# Altium schematic sheet user_interface.SchDoc(user_interface)
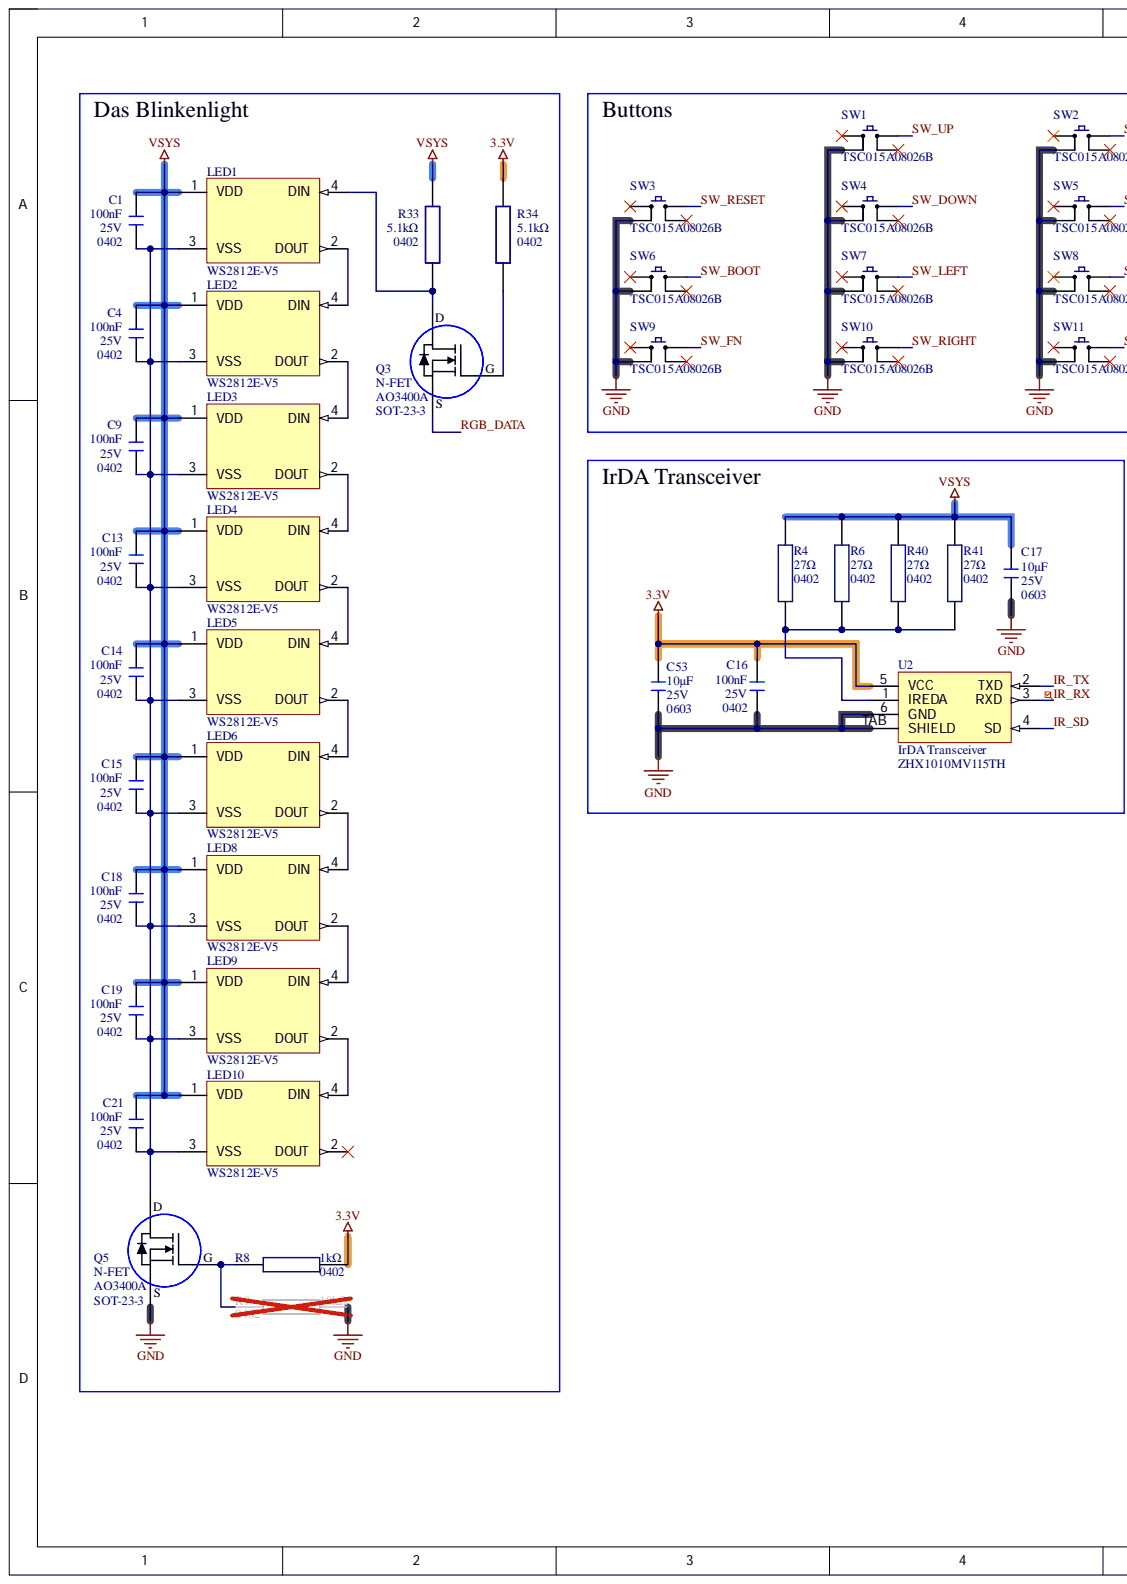
[diagram p0: user_interface.SchDoc, left]
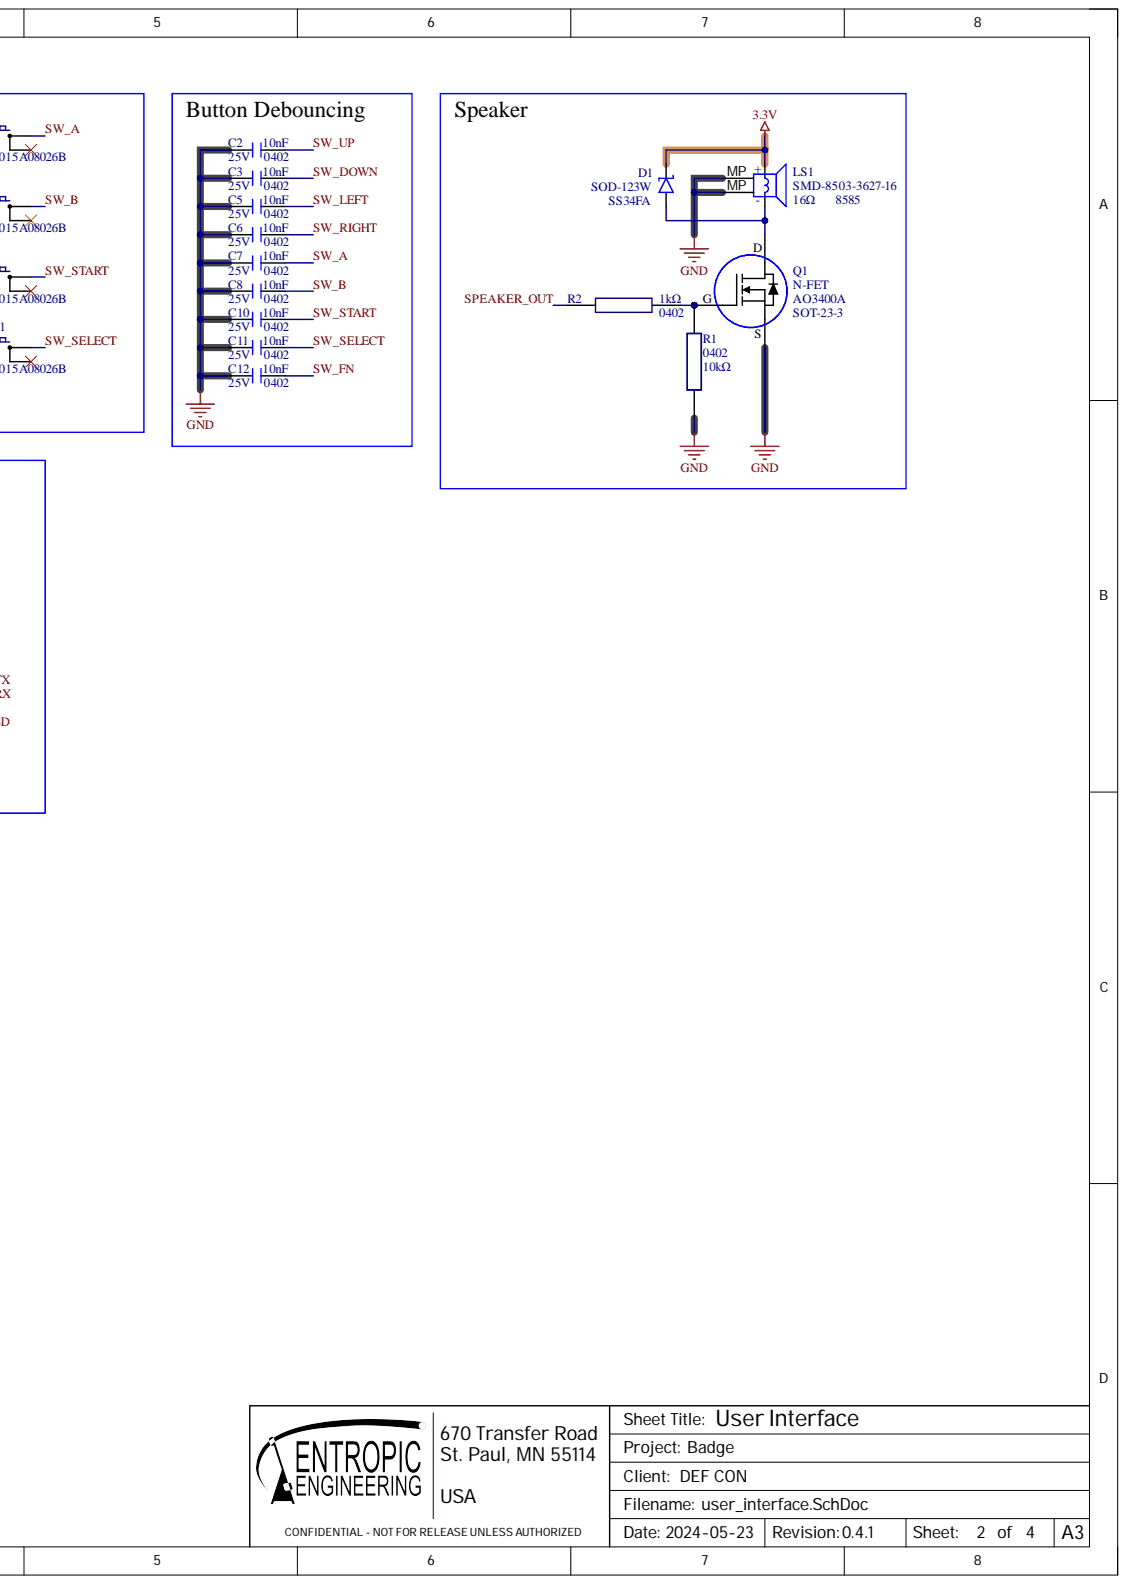
[diagram p1: user_interface.SchDoc, right]

## Parts
C1  Capacitance: 100nF  Case Code (Imperial): 0402  Voltage: 25V  [0402_capacitor]  (hidden comment: GRM155R71E104KE14D)
C2  Capacitance: 10nF  Case Code (Imperial): 0402  Voltage Rating: 25V  [0402_capacitor]  (hidden comment: CL05B103KA5NNNC)
C3  Capacitance: 10nF  Case Code (Imperial): 0402  Voltage Rating: 25V  [0402_capacitor]  (hidden comment: CL05B103KA5NNNC)
C4  Capacitance: 100nF  Case Code (Imperial): 0402  Voltage: 25V  [0402_capacitor]  (hidden comment: GRM155R71E104KE14D)
C5  Capacitance: 10nF  Case Code (Imperial): 0402  Voltage Rating: 25V  [0402_capacitor]  (hidden comment: CL05B103KA5NNNC)
C6  Capacitance: 10nF  Case Code (Imperial): 0402  Voltage Rating: 25V  [0402_capacitor]  (hidden comment: CL05B103KA5NNNC)
C7  Capacitance: 10nF  Case Code (Imperial): 0402  Voltage Rating: 25V  [0402_capacitor]  (hidden comment: CL05B103KA5NNNC)
C8  Capacitance: 10nF  Case Code (Imperial): 0402  Voltage Rating: 25V  [0402_capacitor]  (hidden comment: CL05B103KA5NNNC)
C9  Capacitance: 100nF  Case Code (Imperial): 0402  Voltage: 25V  [0402_capacitor]  (hidden comment: GRM155R71E104KE14D)
C10  Capacitance: 10nF  Case Code (Imperial): 0402  Voltage Rating: 25V  [0402_capacitor]  (hidden comment: CL05B103KA5NNNC)
C11  Capacitance: 10nF  Case Code (Imperial): 0402  Voltage Rating: 25V  [0402_capacitor]  (hidden comment: CL05B103KA5NNNC)
C12  Capacitance: 10nF  Case Code (Imperial): 0402  Voltage Rating: 25V  [0402_capacitor]  (hidden comment: CL05B103KA5NNNC)
C13  Capacitance: 100nF  Case Code (Imperial): 0402  Voltage: 25V  [0402_capacitor]  (hidden comment: GRM155R71E104KE14D)
C14  Capacitance: 100nF  Case Code (Imperial): 0402  Voltage: 25V  [0402_capacitor]  (hidden comment: GRM155R71E104KE14D)
C15  Capacitance: 100nF  Case Code (Imperial): 0402  Voltage: 25V  [0402_capacitor]  (hidden comment: GRM155R71E104KE14D)
C16  Capacitance: 100nF  Case Code (Imperial): 0402  Voltage: 25V  [0402_capacitor]  (hidden comment: GRM155R71E104KE14D)
C17  value 10µF  Case Code (Imperial): 0603  Voltage Rating: 25V  [0603_capacitor]  (hidden comment: CL10A106MA8NRNC)
C18  Capacitance: 100nF  Case Code (Imperial): 0402  Voltage: 25V  [0402_capacitor]  (hidden comment: GRM155R71E104KE14D)
C19  Capacitance: 100nF  Case Code (Imperial): 0402  Voltage: 25V  [0402_capacitor]  (hidden comment: GRM155R71E104KE14D)
C21  Capacitance: 100nF  Case Code (Imperial): 0402  Voltage: 25V  [0402_capacitor]  (hidden comment: GRM155R71E104KE14D)
C53  value 10µF  Case Code (Imperial): 0603  Voltage Rating: 25V  [0603_capacitor]  (hidden comment: CL10A106MA8NRNC)
D1  SS34FA  Case/Package: SOD-123W  [SOD-123]
LED1  WS2812E-V5  [WS2812E-V5]
LED2  WS2812E-V5  [WS2812E-V5]
LED3  WS2812E-V5  [WS2812E-V5]
LED4  WS2812E-V5  [WS2812E-V5]
LED5  WS2812E-V5  [WS2812E-V5]
LED6  WS2812E-V5  [WS2812E-V5]
LED8  WS2812E-V5  [WS2812E-V5]
LED9  WS2812E-V5  [WS2812E-V5]
LED10  WS2812E-V5  [WS2812E-V5]
LS1  SMD-8503-3627-16  Resistance: 16Ω  [8585_speaker]
Q1  N-FET  Case/Package: SOT-23-3  [SOT95P280X115-3N]
Q3  N-FET  Case/Package: SOT-23-3  [SOT95P280X115-3N]
Q5  N-FET  Case/Package: SOT-23-3  [SOT95P280X115-3N]
R1  value 10kΩ  Case Code (Imperial): 0402  [0402_resistor]  (hidden comment: RC0402FR-0710KL)
R2  value 1kΩ  Case Code (Imperial): 0402  [0402_resistor]  (hidden comment: RC0402JR-071KL)
R4  Resistance: 27Ω  Size Code: 0402  [0402_resistor]  (hidden comment: RMCF0402FT27R0)
R6  Resistance: 27Ω  Size Code: 0402  [0402_resistor]  (hidden comment: RMCF0402FT27R0)
R8  value 1kΩ  Case Code (Imperial): 0402  [0402_resistor]  (hidden comment: RC0402JR-071KL)
R9  value 10kΩ  Case Code (Imperial): 0402  [0402_resistor]  (hidden comment: RC0402FR-0710KL)
R33  value 5.1kΩ  Case Code (Imperial): 0402  [0402_resistor]  (hidden comment: RC0402FR-075K1L)
R34  value 5.1kΩ  Case Code (Imperial): 0402  [0402_resistor]  (hidden comment: RC0402FR-075K1L)
R40  Resistance: 27Ω  Size Code: 0402  [0402_resistor]  (hidden comment: RMCF0402FT27R0)
R41  Resistance: 27Ω  Size Code: 0402  [0402_resistor]  (hidden comment: RMCF0402FT27R0)
SW1  Up  [TSC015A08026B]  (value not drawn)
SW2  A  [TSC015A08026B]  (value not drawn)
SW3  Reset  [TSC015A08026B]  (value not drawn)
SW4  Down  [TSC015A08026B]  (value not drawn)
SW5  B  [TSC015A08026B]  (value not drawn)
SW6  Boot  [TSC015A08026B]  (value not drawn)
SW7  Left  [TSC015A08026B]  (value not drawn)
SW8  Start  [TSC015A08026B]  (value not drawn)
SW9  FN  [TSC015A08026B]  (value not drawn)
SW10  Right  [TSC015A08026B]  (value not drawn)
SW11  Select  [TSC015A08026B]  (value not drawn)
U2  IrDA Transceiver  [ZHX1010]

## Nets
3.3V: C16.1 C53.2 D1.K LS1.+ R8.1 R34.2 U2.5(VCC)
GND: C2.1 C3.1 C5.1 C6.1 C7.1 C8.1 C10.1 C11.1 C12.1 C16.2 C17.1 C53.1 LS1.MP Q1.2 Q5.2 R1.2 R9.2 SW1.2 SW2.2 SW3.2 SW4.2 SW5.2 SW6.2 SW7.2 SW8.2 SW9.2 SW10.2 SW11.2 U2.6(GND) U2.TAB(SHIELD)
VSYS: C1.2 C4.2 C9.2 C13.2 C14.2 C15.2 C17.2 C18.2 C19.2 C21.2 LED1.1(VDD) LED2.1(VDD) LED3.1(VDD) LED4.1(VDD) LED5.1(VDD) LED6.1(VDD) LED8.1(VDD) LED9.1(VDD) LED10.1(VDD) R4.2 R6.2 R33.2 R40.2 R41.2
IR_RX: U2.3(RXD)
IR_SD: U2.4(SD)
IR_TX: U2.2(TXD)
RGB_DATA: Q3.2
SPEAKER_OUT: R2.1
SW_A: C7.2 SW2.3
SW_B: C8.2 SW5.3
SW_BOOT: SW6.3
SW_DOWN: C3.2 SW4.3
SW_FN: C12.2 SW9.3
SW_LEFT: C5.2 SW7.3
SW_RESET: SW3.3
SW_RIGHT: C6.2 SW10.3
SW_SELECT: C11.2 SW11.3
SW_START: C10.2 SW8.3
SW_UP: C2.2 SW1.3
NetC1_1: C1.1 C4.1 C9.1 C13.1 C14.1 C15.1 C18.1 C19.1 C21.1 LED1.3(VSS) LED2.3(VSS) LED3.3(VSS) LED4.3(VSS) LED5.3(VSS) LED6.3(VSS) LED8.3(VSS) LED9.3(VSS) LED10.3(VSS) Q5.3
NetD1_A: D1.A LS1.- Q1.3
NetLED1_2: LED1.2(DOUT) LED2.4(DIN)
NetLED1_4: LED1.4(DIN) Q3.3 R33.1
NetLED2_2: LED2.2(DOUT) LED3.4(DIN)
NetLED3_2: LED3.2(DOUT) LED4.4(DIN)
NetLED4_2: LED4.2(DOUT) LED5.4(DIN)
NetLED5_2: LED5.2(DOUT) LED6.4(DIN)
NetLED6_2: LED6.2(DOUT) LED8.4(DIN)
NetLED8_2: LED8.2(DOUT) LED9.4(DIN)
NetLED9_2: LED9.2(DOUT) LED10.4(DIN)
NetQ1_1: Q1.1 R1.1 R2.2
NetQ3_1: Q3.1 R34.1
NetQ5_1: Q5.1 R8.2 R9.1
NetR4_1: R4.1 R6.1 R40.1 R41.1 U2.1(IREDA)

Not connected: LED10 2(DOUT); SW1 1 4; SW2 1 4; SW3 1 4; SW4 1 4; SW5 1 4; SW6 1 4; SW7 1 4; SW8 1 4; SW9 1 4; SW10 1 4; SW11 1 4

## Library data of the parts
C1 C4 C9 C13-C16 C18 C19 C21 = GRM155R71E104KE14D: 100 nF, 25 V, X7R, 0402 Chip capacitor; house_part_number: C-100nF-0402-002; Manufacturer: Murata; Dielectric Material: Ceramic; Tolerance: 10%; Case Code (Metric): 1005; Case/Package: 0402; Category: Ceramic Capacitors; Component Kind: Standard; ComponentLink1Description: http://www.farnell.com/datasheets/1872206.pdf?src-supplier=Farnell; ComponentLink1URL: http://www.farnell.com/datasheets/1872206.pdf?src-supplier=Farnell; ComponentLink2Description: http://components.arrow.com/part/dataview/0323_06361249?src-supplier=Arrow+Europe; ComponentLink2URL: http://components.arrow.com/part/dataview/0323_06361249?src-supplier=Arrow+Europe; ComponentLink3Description: http://uk.rs-online.com/webdocs/144c/0900766b8144c113.pdf?src-supplier=RSComponents; ComponentLink3URL: http://uk.rs-online.com/webdocs/144c/0900766b8144c113.pdf?src-supplier=RSComponents; ComponentLink4Description: http://www.farnell.com/datasheets/2048008.pdf?src-supplier=Farnell; ComponentLink4URL: http://www.farnell.com/datasheets/2048008.pdf?src-supplier=Farnell; ComponentLink5Description: http://www.mouser.com/ds/2/281/c02e-2905.pdf?src-supplier=Mouser; ComponentLink5URL: http://www.mouser.com/ds/2/281/c02e-2905.pdf?src-supplier=Mouser; ComponentLink6Description: http://www.farnell.com/datasheets/2048008.pdf?src-supplier=Newark; ComponentLink6URL: http://www.farnell.com/datasheets/2048008.pdf?src-supplier=Newark; ComponentLink7Description: https://search.murata.co.jp/Ceramy/image/img/A01X/G101/ENG/GRM155R71E104KE14-01.pdf?src-supplier=Digi-Key; ComponentLink7URL: https://search.murata.co.jp/Ceramy/image/img/A01X/G101/ENG/GRM155R71E104KE14-01.pdf?src-supplier=Digi-Key; ComponentLink8Description: http://www.farnell.com/datasheets/2048008.pdf?src-supplier=Element14; ComponentLink8URL: http://www.farnell.com/datasheets/2048008.pdf?src-supplier=Element14; ComponentLink9Description: http://psearch.en.murata.com/capacitor/product/GRM155R71E104KE14%23.pdf?src-supplier=Avnet; ComponentLink9URL: http://psearch.en.murata.com/capacitor/product/GRM155R71E104KE14%23.pdf?src-supplier=Avnet; Depth: 0.5mm; Dielectric: X7R; Height: 0.5mm; Lead Free: Lead Free; Length: 1mm; Manufacturer Part Number: GRM155R71E104KE14D; Max Operating Temperature: 125°C; Min Operating Temperature: -55°C; Mount: Surface Mount; Package Quantity: 10000; Packaging: Tape and Reel; Pricing 1: 1=0.10000, 10=0.02700, 50=0.01460, 100=0.01190, 500=0.00848, 1000=0.00666, 2500=0.00605, 5000=0.00557 (USD); REACH SVHC: Unknown; Resistor Type: Ceramic; RoHS: Yes; RoHS Compliant: Yes; Supplier 1: Digi-Key; Supplier Part Number 1: 490-10698-1-ND; Termination: SMD/SMT; Thickness: 0.022inch; Voltage Rating: 25V; Voltage Rating (DC): 25V; Weight: 0.000212oz; Width: 0.02inch; Library Name: defcon_badge.SchLib
C2 C3 C5-C8 C10-C12 = CL05B103KA5NNNC: 10 nF, 25 V, X7R, 0402 Chip capacitor; house_part_number: C-10nF-0402-002; Manufacturer: Samsung; Tolerance: 10%; Case Code (Metric): 1005; Case/Package: 0402; Category: Ceramic Capacitors; Component Kind: Standard; ComponentLink1Description: https://datasheet.ciiva.com/15195/getdatasheetpartid-358612-15195635.pdf?src-supplier=Verical; ComponentLink1URL: https://datasheet.ciiva.com/15195/getdatasheetpartid-358612-15195635.pdf?src-supplier=Verical; ComponentLink2Description: https://datasheet.ciiva.com/16740/cl05b104kq5nnnc-16740683.pdf?src-supplier=Future+Electronics; ComponentLink2URL: https://datasheet.ciiva.com/16740/cl05b104kq5nnnc-16740683.pdf?src-supplier=Future+Electronics; Construction: Flat; Contact Plating: Tin; Depth: 0.5mm; Dielectric: X7R; Height: 0.5mm; Length: 1mm; Manufacturer Part Number: CL05B103KA5NNNC; Max Operating Temperature: 125°C; Min Operating Temperature: -55°C; Mount: Surface Mount; Package Quantity: 1; Packaging: Tape and Reel; Pricing 1: 10000=0.00328, 20000=0.00312, 30000=0.00297, 50000=0.00266, 100000=0.00215, 250000=0.00195 (USD); Radiation Hardening: No; RoHS: Yes; RoHS Compliant: Yes; Series: CL; Stock 1: 2318300; Supplier 1: Digi-Key; Supplier Part Number 1: 1276-1057-2-ND; Thickness: 0.022inch; Width: 0.02inch; Library Name: defcon_badge.SchLib
C17 C53 = CL10A106MA8NRNC: 10 µF, 25 V, X5R, 0603 Chip capacitor; house_part_number: C-10uF-0603-002; Manufacturer: Samsung; Material: 4241ConductorMaterial; Tolerance: 20%; Case Code (Metric): 1608; Case/Package: 0603; Category: Ceramic Capacitors; Component Kind: Standard; ComponentLink1Description: https://datasheet.ciiva.com/25766/cl10a106ma8nrnc-25766562.pdf?src-supplier=Digikey; ComponentLink1URL: https://datasheet.ciiva.com/25766/cl10a106ma8nrnc-25766562.pdf?src-supplier=Digikey; Height: 900um; Lead Free: Lead Free; Manufacturer Part Number: CL10A106MA8NRNC; Max Operating Temperature: 85°C; Min Operating Temperature: -55°C; Mounting Technology: Surface Mount; Packaging: Cut Tape; Pricing: 4000=0.0511, 8000=0.046, 12000=0.0434, 28000=0.0413, 100000=0.0356 (USD); RoHS: Yes; RoHS Compliant: Yes; Series: CL; Stock: 632000; Supplier 1: Arrow Electronics; Supplier Part Number 1: CL10A106MA8NRNC; Library Name: defcon_badge.SchLib
D1 = SS34FA: 40 V, 3 A Schottky Rectifier, SOD-123FA; house_part_number: D-SCHOTTKY-3A-SOD123F-002; Manufacturer: ON Semiconductor / Fairchild; Average Rectified Current: 3A; Element Configuration: Single; Max Forward Surge Current (Ifsm): 80A; Max Repetitive Reverse Voltage (Vrrm): 40V; Category: Schottky Diodes; Component Kind: Standard; ComponentLink1Description: http://www.farnell.com/datasheets/2552647.pdf?src-supplier=Farnell; ComponentLink1URL: http://www.farnell.com/datasheets/2552647.pdf?src-supplier=Farnell; ComponentLink2Description: http://www.mouser.com/ds/2/149/SS34FA-1012641.pdf?src-supplier=Mouser; ComponentLink2URL: http://www.mouser.com/ds/2/149/SS34FA-1012641.pdf?src-supplier=Mouser; ComponentLink3Description: http://www.farnell.com/datasheets/2552647.pdf?src-supplier=Element14; ComponentLink3URL: http://www.farnell.com/datasheets/2552647.pdf?src-supplier=Element14; ComponentLink4Description: http://www.farnell.com/datasheets/2552647.pdf?src-supplier=Newark; ComponentLink4URL: http://www.farnell.com/datasheets/2552647.pdf?src-supplier=Newark; ComponentLink5Description: https://www.fairchildsemi.com/datasheets/SS/SS34FA.pdf?src-supplier=Digikey; ComponentLink5URL: https://www.fairchildsemi.com/datasheets/SS/SS34FA.pdf?src-supplier=Digikey; ComponentLink6Description: https://www.onsemi.com/pub/Collateral/SS36FA-D.PDF?src-supplier=Digikey; ComponentLink6URL: https://www.onsemi.com/pub/Collateral/SS36FA-D.PDF?src-supplier=Digikey; ComponentLink7Description: https://datasheet.octopart.com/SS34FA-ON-Semiconductor-datasheet-137713515.pdf?src-supplier=TME; ComponentLink7URL: https://datasheet.octopart.com/SS34FA-ON-Semiconductor-datasheet-137713515.pdf?src-supplier=TME; Manufacturer Part Number: SS34FA; Max Reverse Voltage (DC): 40V; Mounting Technology: Surface Mount; Packaging: Cut Tape; Pricing: 1=0.469, 25=0.4, 50=0.341, 100=0.281, 250=0.251 (USD); RoHS: Yes; RoHS Compliant: Yes; Series: Automotive, AEC-Q101; Stock: 2402; Supplier: Avnet; Supplier 1: LCSC; Supplier Part Number: 01AC9736; Supplier Part Number 1: C719833; Weight: 0.019g; Library Name: defcon_badge.SchLib
LED1-LED6 LED8-LED10 = WS2812E-V5: 1-wire addressable RGB LED; Manufacturer: WORLDSEMI; Category: Uncategorized; Component Kind: Standard; house_part_number: WS2812E-V5; Manufacturer Part Number: WS2812E-V5; Pricing 1: 10=0.0559, 100=0.0464, 300=0.0416, 1000=0.038, 4500=0.0315, 9000=0.0301 (USD); RoHS: No; Stock 1: 20520; Supplier 1: LCSC; Supplier Part Number 1: C2920042; Library Name: defcon_badge.SchLib
LS1 = SMD-8503-3627-16: 16 Ω, 8.5 mm x 8.5 mm, SMD speaker; Manufacturer: S&S; Category: Uncategorized; Component Kind: Standard; house_part_number: SMD-8503-3627-16; Manufacturer Part Number: SMD-8503-3627-16; package: 8585; Pricing 1: 5=0.2676, 50=0.2148, 150=0.1922, 1000=0.1559, 2000=0.1433, 5000=0.1358 (USD); RoHS: No; Stock 1: 6390; Supplier 1: LCSC; Supplier Part Number 1: C2685545; Library Name: defcon_badge.SchLib
Q1 Q3 Q5 = AO3400A: N-FET, 30V, 5.7A, SOT-23-3; Manufacturer: Alpha & Omega Semiconductor; Drain to Source Resistance: 18mR; Input Capacitance: 630pF; Max Power Dissipation: 1.4W; Number of Channels: 1NUMBER; Rds On Max: 26.5mR; Threshold Voltage: 650mV; Turn-Off Delay Time: 25ns; Turn-On Delay Time: 3ns; Category: MOSFETs; Component Kind: Standard; ComponentLink1Description: https://datasheet.ciiva.com/940/ao3400a-940739.pdf?src-supplier=Digikey; ComponentLink1URL: https://datasheet.ciiva.com/940/ao3400a-940739.pdf?src-supplier=Digikey; ComponentLink2Description: https://datasheet.ciiva.com/26906/getdatasheetpartid-64438-26906526.pdf?src-supplier=Verical; ComponentLink2URL: https://datasheet.ciiva.com/26906/getdatasheetpartid-64438-26906526.pdf?src-supplier=Verical; ComponentLink3Description: https://datasheet.ciiva.com/940/ao3400a-940740.pdf?src-supplier=Digikey; ComponentLink3URL: https://datasheet.ciiva.com/940/ao3400a-940740.pdf?src-supplier=Digikey; ComponentLink4Description: https://datasheet.ciiva.com/940/ao3400a-940741.pdf?src-supplier=Digikey; ComponentLink4URL: https://datasheet.ciiva.com/940/ao3400a-940741.pdf?src-supplier=Digikey; Continuous Drain Current (ID): 5.7A; Drain to Source Breakdown Voltage: 30V; Drain to Source Voltage (Vdss): 30V; Height: 1.25mm; house_part_number: AO3400A; Lead Free: Lead Free; Manufacturer Part Number: AO3400A; Max Operating Temperature: 150°C; Min Operating Temperature: -55°C; Mounting Technology: Surface Mount; Packaging: Cut Tape; Pricing: 3000=0.0899, 6000=0.0869, 9000=0.0763, 30000=0.0746, 75000=0.0627 (USD); RoHS: Yes; RoHS Compliant: Yes; Series: -; Stock: 141000; Supplier: Arrow Electronics; Supplier Part Number 1: AO3400A; Library Name: defcon_badge.SchLib
R1 R9 = RC0402FR-0710KL: 10 kΩ, 0402 Chip resistor; house_part_number: R-10k-0402-1%-001; Manufacturer: Yageo; Max Power Dissipation: 63mW; Power Rating: 62.5mW; Resistance Tolerance: 1%; Temperature Coefficient: 100ppm/°C; Voltage Rating: 50V; Case Code (Metric): 1005; Case/Package: 0402; Category: Chip SMD Resistors; Component Kind: Standard; ComponentLink1Description: https://datasheet.ciiva.com/12667/pyu-rc0402-51-rohs-l-6-r-12667067.pdf?src-supplier=Mouser; ComponentLink1URL: https://datasheet.ciiva.com/12667/pyu-rc0402-51-rohs-l-6-r-12667067.pdf?src-supplier=Mouser; Construction: Rectangular; Depth: 0.5mm; Features: Moisture Resistant; Lead Free: 5697LeadFree; Lead Pitch: 0.2mm; Length: 1mm; Manufacturer Part Number: RC0402FR-0710KL; Max Operating Temperature: 155°C; Military Standard: Not; Min Operating Temperature: -55°C; Number of Terminations: 2; Packaging: Tape and Reel; Pins: 2; Pricing: 1=0.1, 10=0.015, 50=0.0088, 100=0.0073, 500=0.00488, 1000=0.00417, 5000=0.00297 (USD); Reach SVHC Compliant: Unknown; Resistor Type: Thick Film; RoHS: Yes; RoHS Compliant: Yes; Series: RC; Stock: 9380606; Supplier 1: Digikey; Supplier Part Number 1: 311-10.0KLRCT-ND; Termination: SMD/SMT; Tolerance: 1%; Voltage Rating (DC): 50V; Width: 0.5mm; Library Name: defcon_badge.SchLib
R2 R8 = RC0402JR-071KL: 1 kΩ, 0402 Chip resistor; house_part_number: R-1k-0402-1%-001; Manufacturer: Yageo; Max Power Dissipation: 63mW; Power Rating: 62.5mW; Resistance Tolerance: 5%; Temperature Coefficient: 100ppm/°C; Voltage Rating: 50V; Case Code (Metric): 1005; Case/Package: 0402; Category: Chip SMD Resistors; Component Kind: Standard; ComponentLink1Description: https://datasheet.ciiva.com/7983/1018594-7983558.pdf?src-supplier=Element14; ComponentLink1URL: https://datasheet.ciiva.com/7983/1018594-7983558.pdf?src-supplier=Element14; ComponentLink2Description: https://datasheet.ciiva.com/2452/pyu-r-int-thick-7-2452756.pdf?src-supplier=Digikey; ComponentLink2URL: https://datasheet.ciiva.com/2452/pyu-r-int-thick-7-2452756.pdf?src-supplier=Digikey; ComponentLink3Description: https://datasheet.ciiva.com/2452/pyu-r-int-thick-7-2452757.pdf?src-supplier=Digikey; ComponentLink3URL: https://datasheet.ciiva.com/2452/pyu-r-int-thick-7-2452757.pdf?src-supplier=Digikey; ComponentLink4Description: https://datasheet.ciiva.com/2452/pyu-r-int-thick-7-2452755.pdf?src-supplier=Digikey; ComponentLink4URL: https://datasheet.ciiva.com/2452/pyu-r-int-thick-7-2452755.pdf?src-supplier=Digikey; ComponentLink5Description: https://datasheet.ciiva.com/7850/1018594-7850170.pdf?src-supplier=Element14; ComponentLink5URL: https://datasheet.ciiva.com/7850/1018594-7850170.pdf?src-supplier=Element14; ComponentLink6Description: https://datasheet.ciiva.com/7850/1018594-7850171.pdf?src-supplier=Element14; ComponentLink6URL: https://datasheet.ciiva.com/7850/1018594-7850171.pdf?src-supplier=Element14; ComponentLink7Description: https://datasheet.ciiva.com/17081/pyu-rc0402-51-rohs-l-6-r-17081438.pdf?src-supplier=Future+Electronics; ComponentLink7URL: https://datasheet.ciiva.com/17081/pyu-rc0402-51-rohs-l-6-r-17081438.pdf?src-supplier=Future+Electronics; Features: Moisture Resistant; Height: 0.016inch; Lead Free: 5697LeadFree; Length: 0.039inch; Manufacturer Part Number: RC0402JR-071KL; Max Operating Temperature: 155°C; Min Operating Temperature: -55°C; Number of Terminations: 2; Package Quantity: 1; Packaging: Cut Tape; Pricing: 1=0.1, 10=0.018, 50=0.0102, 100=0.0083, 500=0.00528, 1000=0.00444, 5000=0.00307 (USD); Reach SVHC Compliant: No; Resistor Type: Thick Film; RoHS: Yes; RoHS Compliant: Yes; Series: RC; Stock: 15490862; Supplier 1: Digikey; Supplier Part Number 1: 311-1.0KJRCT-ND; Termination: SMD/SMT; Tolerance: 5%; Voltage Rating (AC): 50V; Width: 0.02inch; Library Name: defcon_badge.SchLib
R4 R6 R40 R41 = RMCF0402FT27R0: 27 Ω, 0402 Chip resistor; house_part_number: R-27-0402-1%-001; Manufacturer: Stackpole Electronics; Package Height: 0.3mm; Package Length: 1mm; Package Width: 0.5mm; Rated Power Dissipation (P): 63mW; Rated Temperature: 70°C; Reference Standard: AEC-Q200; Temperature Coefficient: 100ppm/°C; Tolerance: 1%; Working Voltage: 50V; Category: Chip SMD Resistors; Component Kind: Standard; ComponentLink1Description: https://datasheet.ciiva.com:443/pdfs/VipMasterIC/IC/SEIE/SEIE-S-A0009541969/SEIE-S-A0009541969-1.pdf?src-supplier=IHS+Markit; ComponentLink1URL: https://datasheet.ciiva.com:443/pdfs/VipMasterIC/IC/SEIE/SEIE-S-A0009541969/SEIE-S-A0009541969-1.pdf?src-supplier=IHS+Markit; ComponentLink2Description: https://www.seielect.com/catalog/SEI-RMCF_RMCP.pdf?src-supplier=Avnet; ComponentLink2URL: https://www.seielect.com/catalog/SEI-RMCF_RMCP.pdf?src-supplier=Avnet; ComponentLink3Description: https://www.seielect.com/catalog/sei-rmcf_rmcp.pdf?src-supplier=Digi-Key; ComponentLink3URL: https://www.seielect.com/catalog/sei-rmcf_rmcp.pdf?src-supplier=Digi-Key; ComponentLink4Description: http://www.seielect.com/Catalog/SEI-RMCF_RMCP.pdf?src-supplier=Digi-Key; ComponentLink4URL: http://www.seielect.com/Catalog/SEI-RMCF_RMCP.pdf?src-supplier=Digi-Key; Construction: Rectangular; JESD-609 Code: e3; Manufacturer Part Number: RMCF0402FT27R0; Max Operating Temperature: 155°C; Min Operating Temperature: -55°C; Mount: Surface Mount; Number of Pins: 2; Package Shape: Rectangular; Package Style: SMTMeter; Packaging: Tape and Reel; Pricing 1: 1=0.10000, 10=0.01500, 100=0.00610, 1000=0.00274, 2500=0.00238, 5000=0.00197 (USD); REACH SVHC: Yes; Resistor Type: FIXED RESISTOR; RoHS: Yes; RoHS Compliant: Yes; Stock 1: 3710; Supplier 1: Digi-Key; Supplier Part Number 1: RMCF0402FT27R0CT-ND; Technology: METAL GLAZE/THICK FILM; Terminal Finish: Nickel; Termination: Wraparound; Library Name: defcon_badge.SchLib
R33 R34 = RC0402FR-075K1L: 5.1 kΩ, 0402 Chip resistor; house_part_number: R-5.1k-0402-1%-001; Manufacturer: Yageo; Max Power Dissipation: 63mW; Resistance Tolerance: 1%; Temperature Coefficient: 100ppm/°C; Voltage Rating: 50V; Case Code (Metric): 1005; Case/Package: 0402; Category: Chip SMD Resistors; Component Kind: Standard; ComponentLink1Description: https://datasheet.ciiva.com/7983/1018594-7983519.pdf?src-supplier=Element14; ComponentLink1URL: https://datasheet.ciiva.com/7983/1018594-7983519.pdf?src-supplier=Element14; ComponentLink2Description: https://datasheet.ciiva.com/46394/1018594-46394936.pdf?src-supplier=Element14; ComponentLink2URL: https://datasheet.ciiva.com/46394/1018594-46394936.pdf?src-supplier=Element14; ComponentLink3Description: https://datasheet.ciiva.com/2453/pyu-r-int-thick-7-2453401.pdf?src-supplier=Digikey; ComponentLink3URL: https://datasheet.ciiva.com/2453/pyu-r-int-thick-7-2453401.pdf?src-supplier=Digikey; ComponentLink4Description: https://datasheet.ciiva.com/2453/pyu-r-int-thick-7-2453402.pdf?src-supplier=Digikey; ComponentLink4URL: https://datasheet.ciiva.com/2453/pyu-r-int-thick-7-2453402.pdf?src-supplier=Digikey; ComponentLink5Description: https://datasheet.ciiva.com/2453/pyu-r-int-thick-7-2453400.pdf?src-supplier=Digikey; ComponentLink5URL: https://datasheet.ciiva.com/2453/pyu-r-int-thick-7-2453400.pdf?src-supplier=Digikey; ComponentLink6Description: https://datasheet.ciiva.com/12558/pyu-rc0402-51-rohs-l-6-r-8721-12558460.pdf?src-supplier=Mouser; ComponentLink6URL: https://datasheet.ciiva.com/12558/pyu-rc0402-51-rohs-l-6-r-8721-12558460.pdf?src-supplier=Mouser; ComponentLink7Description: https://datasheet.ciiva.com/16744/pyu-rc0402-51-rohs-l-6-r-16744283.pdf?src-supplier=Future+Electronics; ComponentLink7URL: https://datasheet.ciiva.com/16744/pyu-rc0402-51-rohs-l-6-r-16744283.pdf?src-supplier=Future+Electronics; ComponentLink8Description: https://datasheet.ciiva.com/15189/getdatasheetpartid-323636-15189493.pdf?src-supplier=Verical; ComponentLink8URL: https://datasheet.ciiva.com/15189/getdatasheetpartid-323636-15189493.pdf?src-supplier=Verical; Construction: Rectangular; Depth: 0.5mm; Features: Moisture Resistant; Height: 0.016inch; Lead Free: 5697LeadFree; Length: 1mm; Manufacturer Part Number: RC0402FR-075K1L; Max Operating Temperature: 155°C; Military Standard: Not; Min Operating Temperature: -55°C; Number of Terminations: 2; Package Quantity: 1; Packaging: Tape and Reel; Power Rating: 63mW; Pricing: 10000=0.0024, 50000=0.0023, 250000=0.0021, 500000=0.0021, 1500000=0.0019 (USD); Reach SVHC Compliant: Unknown; Resistor Type: Thick Film; RoHS: Yes; RoHS Compliant: Yes; Series: RC; Stock: 1190000; Supplier 1: Future Electronics; Supplier Part Number 1: 6053649; Technology: THICK FILM; Termination: SMD/SMT; Tolerance: 1%; Voltage Rating (DC): 50V; Width: 0.02inch; Working Voltage: 50V; Library Name: defcon_badge.SchLib
SW1-SW11 = TSC015A08026B: Tactile switch, SPST; house_part_number: SW-TAC-4.5-8-260gf; Actuator Type: Standard; Illumination Type: Non-Illuminated; Mechanical Life: 100000Cycles; Operating Force: 260gf; Ratings: IP40; Switch Function: Off-Mom; Switch Travel: 0.25mm; Category: Tactile Switches; Component Kind: Standard; ComponentLink1Description: https://www.ckswitches.com/media/2567/pts647.pdf?src-supplier=Digi-Key; ComponentLink1URL: https://www.ckswitches.com/media/2567/pts647.pdf?src-supplier=Digi-Key; Height: 8mm; Manufacturer Part Number: TSC015A08026B; Max Operating Temperature: 70°C; Min Operating Temperature: -20°C; Mount: Surface Mount; Packaging: Tape and Reel; Pricing 1: 1=0.13000, 10=0.12700, 25=0.12080, 100=0.10970, 250=0.10308, 500=0.09432, 1000=0.07897 (USD); RoHS: No; Stock 1: 68566; Termination: Gull Wing; Throw Configuration: SPST; Library Name: defcon_badge.SchLib
U2 = ZHX1010MV115TH: IRDA MODULE 115.2KBPS 6SMD; Manufacturer: Ixys Zilog; Operating Supply Voltage: 5.5V; Wavelength: 875nm; Case/Package: Module; Category: Optical Sensors; Component Kind: Standard; house_part_number: ZHX1010MV115TH; Lead Free: Contains Lead; Manufacturer Part Number: ZHX1010MV115TH; Max Operating Temperature: 85°C; Min Operating Temperature: -30°C; Orientation: Side View; Packaging: Tape and Reel; RoHS: No; Size: 4mm; Supplier 1: Digikey; Supplier Part Number 1: ZHX1010MV115TH-ND; Library Name: defcon_badge.SchLib
